# Altium schematic sheet GT-U7模块.SchDoc
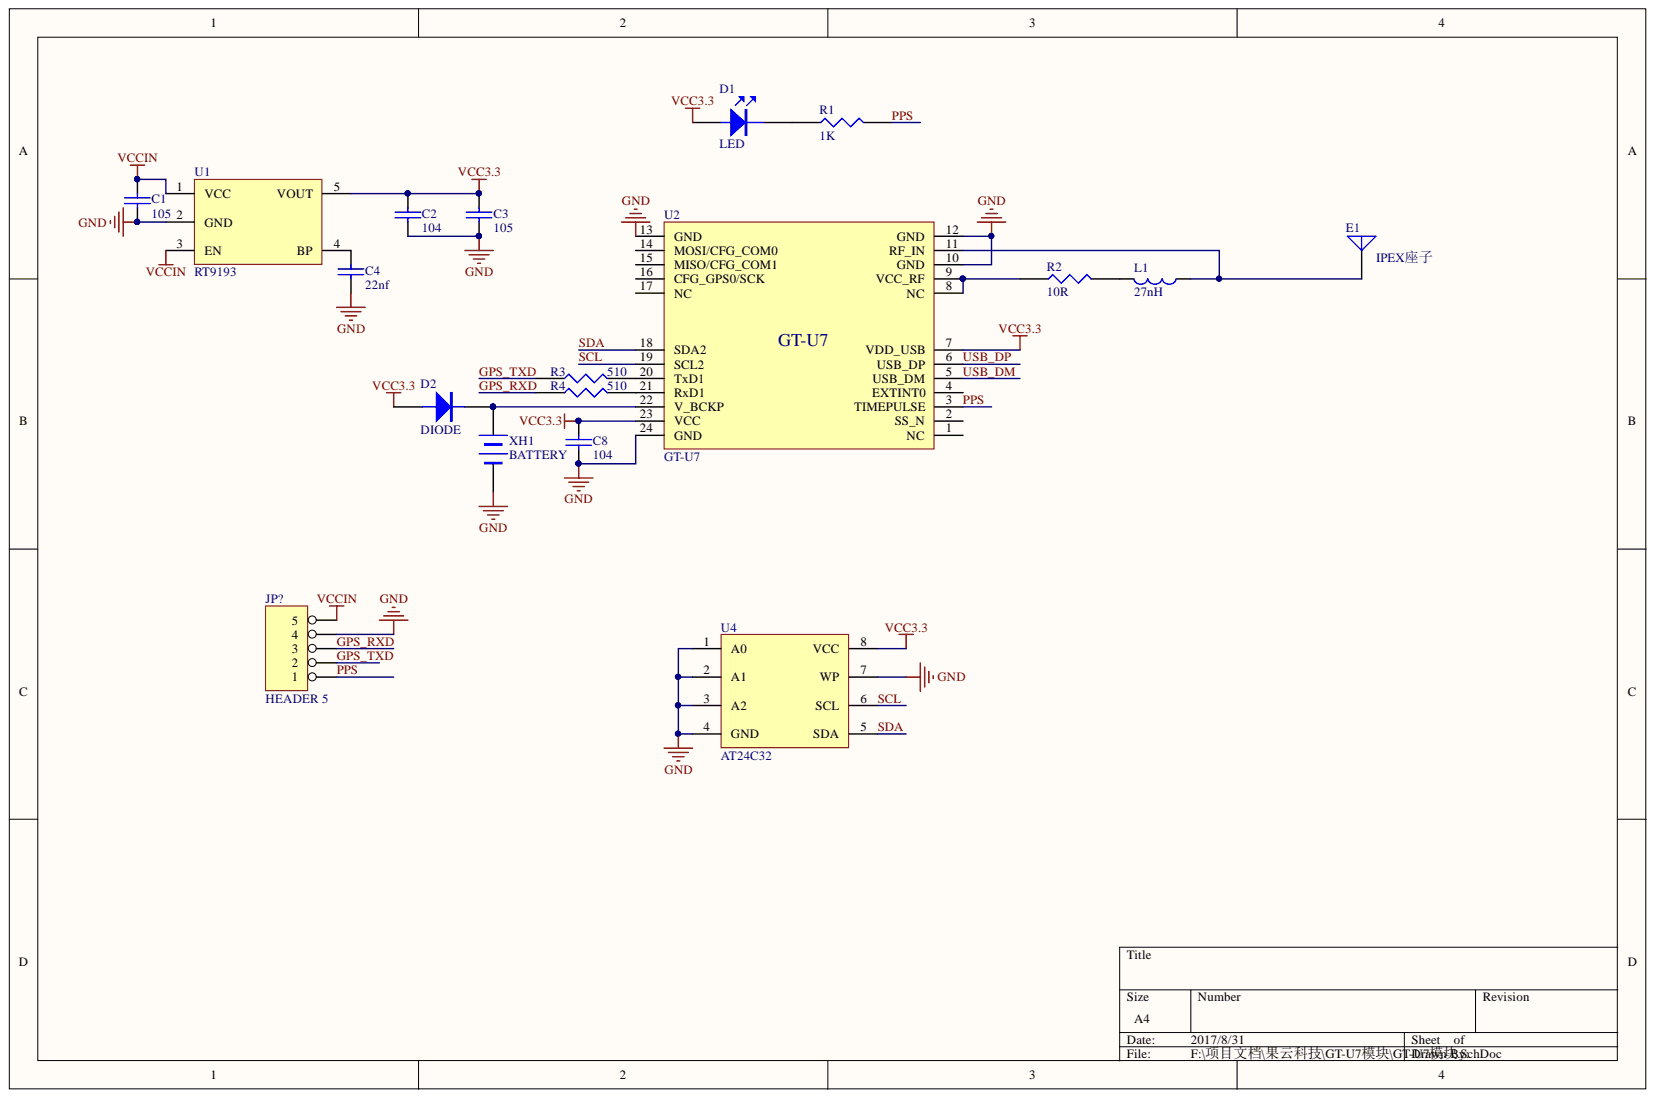
[diagram p0: GT-U7模块.SchDoc]

## Parts
C1  105  [0603C]
C2  104  [0603C]
C3  105  [0603C]
C4  22nf  [0603C]
C8  104  [0603C]
D1  LED  [0603D]
D2  DIODE  [1206D]
E1  IPEX座子  [IPX座子]  (value not drawn)
JP?  HEADER 5  [HDR1X5]
L1  27nH  [0603B]
R1  1K  [0603B]
R2  10R  [0603B]
R3  510  [0603B]
R4  510  [0603B]
U1  RT9193  [SOT23-5]
U2  GT-U7
U4  AT24C32  [TTSOP8]
XH1  BATTERY  [XH414]

## Nets
GND: C1.2 C2.2 C3.2 C4.2 C8.2 JP?.4 U1.2(GND) U2.10(GND) U2.12(GND) U2.13(GND) U2.24(GND) U4.1(A0) U4.2(A1) U4.3(A2) U4.4(GND) U4.7(WP) XH1.2
VCC3.3: C2.1 C3.1 C8.1 D1.A D2.1 U1.5(VOUT) U2.7(VDD_USB) U2.23(VCC) U4.8(VCC)
VCCIN: C1.1 JP?.5 U1.1(VCC) U1.3(EN)
GPS_RXD: JP?.3 R4.1
GPS_TXD: JP?.2 R3.1
PPS: JP?.1 R1.2 U2.3(TIMEPULSE)
SCL: U2.19(SCL2) U4.6(SCL)
SDA: U2.18(SDA2) U4.5(SDA)
USB_DM: U2.5(USB_DM)
USB_DP: U2.6(USB_DP)
NetC4_1: C4.1 U1.4(BP)
NetD1_K: D1.K R1.1
NetD2_2: D2.2 U2.22(V_BCKP) XH1.1
NetE1_1: E1.1 L1.2 U2.11(RF_IN)
NetL1_1: L1.1 R2.2
NetR2_1: R2.1 U2.8(NC) U2.9(VCC_RF)
NetR3_2: R3.2 U2.20(TxD1)
NetR4_2: R4.2 U2.21(RxD1)

Not connected: U2 1(NC) 2(SS_N) 4(EXTINT0) 14(MOSI/CFG_COM0) 15(MISO/CFG_COM1) 16(CFG_GPS0/SCK) 17(NC)

## Library data of the parts
C1-C4 C8 = CAP: Capacitor; Component Kind: Standard; Library Name: Miscellaneous Devices.SCHLIB
E1 = ANTENNA: Generic Antenna; Component Kind: Standard; Library Name: Miscellaneous Devices.SCHLIB
L1 = L1: 电感; Component Kind: Standard; Library Name: RCDQLY.SCHLIB
R1-R4 = RES1: Component Kind: Standard; Library Name: Miscellaneous Devices.SCHLIB
D1 = LED: Component Kind: Standard; Library Name: Miscellaneous Devices.SCHLIB
D2 = DIODE: Component Kind: Standard; Description: Diode; Library Name: Miscellaneous Devices.SCHLIB
JP? = HEADER 5: Component Kind: Standard; Library Name: Miscellaneous Devices.SCHLIB
U1 = RT9193: Component Kind: Standard; Library Name: NEO-6M-GPS.SchLib
U2 = GT-U7: Component Kind: Standard; Library Name: NEO-6M-GPS.SchLib
U4 = AT24C32: Component Kind: Standard; Library Name: NEO-6M-GPS.SchLib
XH1 = BATTERY: Component Kind: Standard; Description: Battery; Library Name: Miscellaneous Devices.SCHLIB
